# Altium schematic sheet Charging_TI_2.SchDoc(Charging_TI_2)
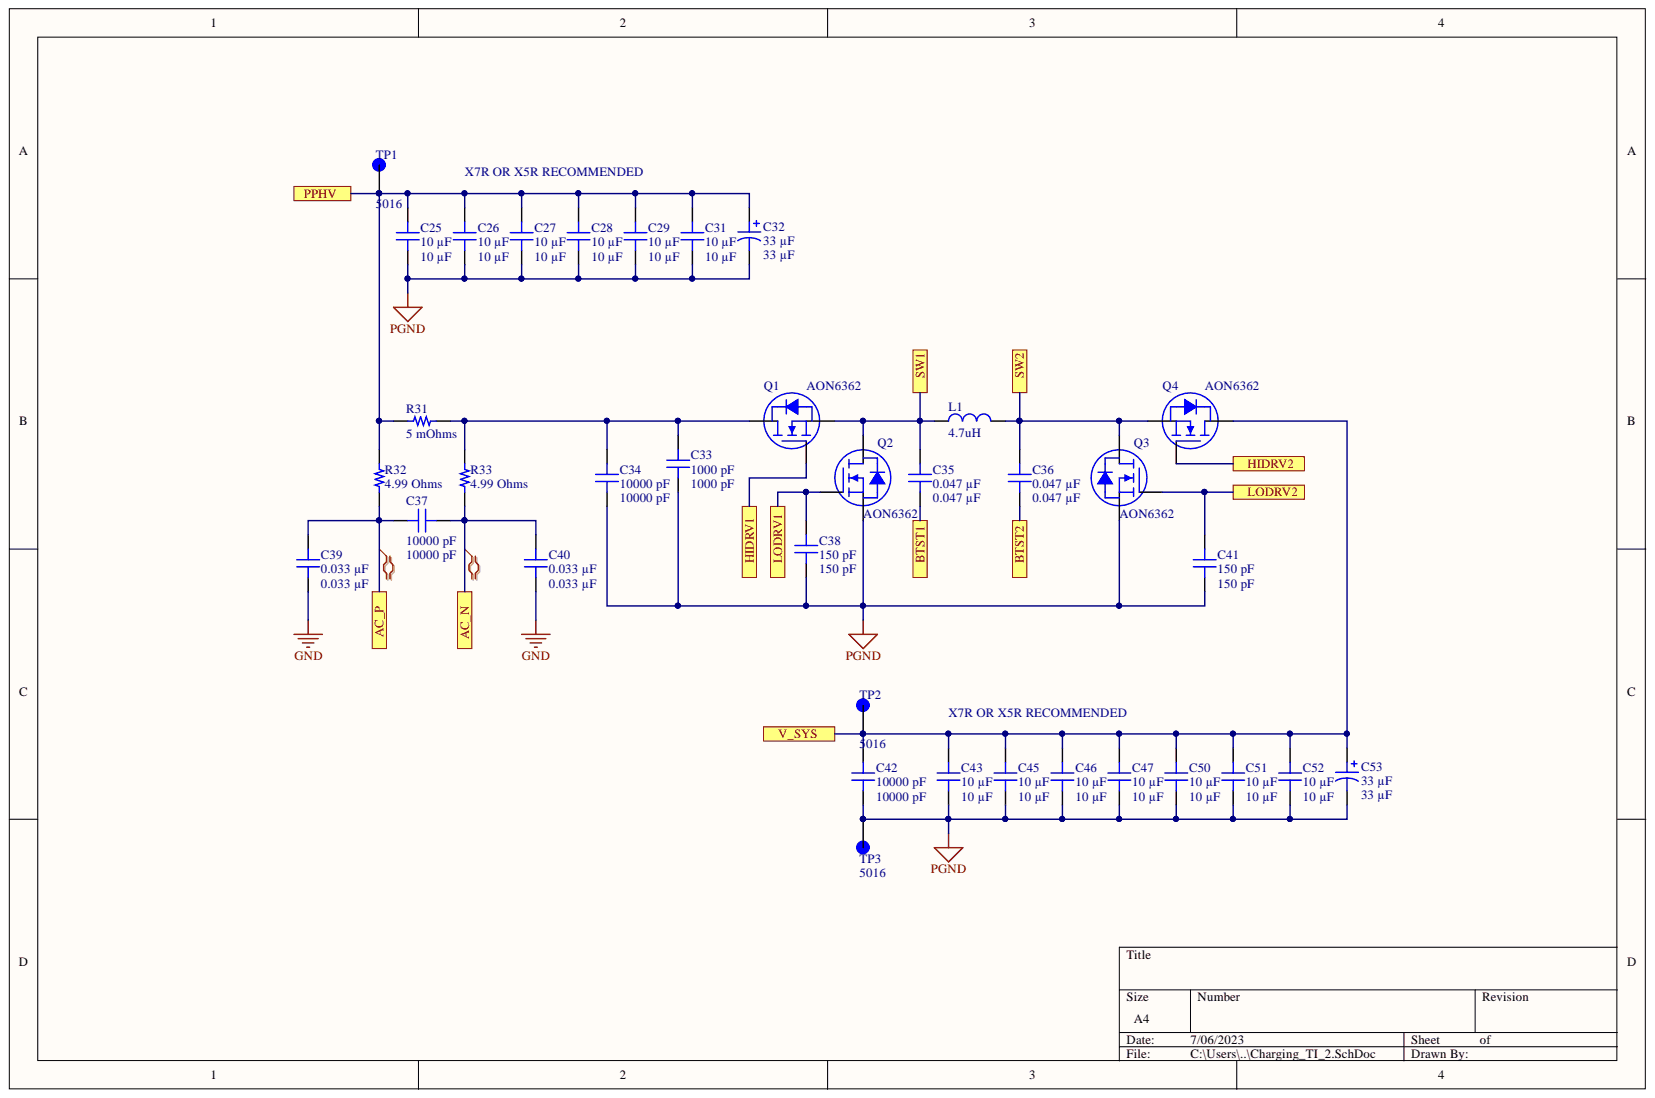
[diagram p0: Charging_TI_2.SchDoc]

## Parts
C25  10 µF  [CAP 0805_2012]
C26  10 µF  [CAP 0805_2012]
C27  10 µF  [CAP 0805_2012]
C28  10 µF  [CAP 0805_2012]
C29  10 µF  [CAP 0805_2012]
C31  10 µF  [CAP 0805_2012]
C32  33 µF  [CAP POL 2917_7343]
C33  1000 pF  [CAP 0402_1005]
C34  10000 pF  [CAP 0402_1005]
C35  0.047 µF  [CAP 0603_1608]
C36  0.047 µF  [CAP 0603_1608]
C37  10000 pF  [CAP 0603_1608]
C38  150 pF  [CAP 0603_1608]
C39  0.033 µF  [CAP 0603_1608]
C40  0.033 µF  [CAP 0603_1608]
C41  150 pF  [CAP 0603_1608]
C42  10000 pF  [CAP 0603_1608]
C43  10 µF  [CAP 0805_2012]
C45  10 µF  [CAP 0805_2012]
C46  10 µF  [CAP 0805_2012]
C47  10 µF  [CAP 0805_2012]
C50  10 µF  [CAP 0805_2012]
C51  10 µF  [CAP 0805_2012]
C52  10 µF  [CAP 0805_2012]
C53  33 µF  [CAP POL 2917_7343]
L1  4.7uH  [BMQADY1010404R7MA1]
Q1  AON6362  [DFN 5x6]
Q2  AON6362  [DFN 5x6]
Q3  AON6362  [DFN 5x6]
Q4  AON6362  [DFN 5x6]
R31  5 mOhms  [RES 1206_3216]
R32  4.99 Ohms  [RES 0603_1608]
R33  4.99 Ohms  [RES 0603_1608]
TP1  5016  [KEYSTONE_5016]
TP2  5016  [KEYSTONE_5016]
TP3  5016  [KEYSTONE_5016]

## Ports
AC_N, AC_P, BTST1, BTST2, HIDRV1, HIDRV2, LODRV1, LODRV2, PPHV, SW1, SW2, V_SYS

## Nets
GND: C39.1 C40.1
PGND: C25.1 C26.1 C27.1 C28.1 C29.1 C31.1 C32.2 C33.1 C34.1 C38.1 C41.1 C42.1 C43.1 C45.1 C46.1 C47.1 C50.1 C51.1 C52.1 C53.2 Q2.S Q3.S TP3.TP
AC_N: C37.1 C40.2 R33.1 ; port AC_N
AC_P: C37.2 C39.2 R32.1 ; port AC_P
BTST1: C35.1 ; port BTST1
BTST2: C36.1 ; port BTST2
HIDRV1: Q1.G ; port HIDRV1
HIDRV2: Q4.G ; port HIDRV2
LODRV1: C38.2 Q2.G ; port LODRV1
LODRV2: C41.2 Q3.G ; port LODRV2
PPHV: C25.2 C26.2 C27.2 C28.2 C29.2 C31.2 C32.1 R31.1 R32.2 TP1.TP ; port PPHV
SW1: C35.2 L1.1 Q1.S Q2.D ; port SW1
SW2: C36.2 L1.2 Q3.D Q4.S ; port SW2
V_SYS: C42.2 C43.2 C45.2 C46.2 C47.2 C50.2 C51.2 C52.2 C53.1 Q4.D TP2.TP ; port V_SYS
NetC33_2: C33.2 C34.2 Q1.D R31.2 R33.2

## Library data of the parts
C25-C29 C31 C43 C45-C47 C50-C52 = GRM21BR61H106KE43L: CAP CER 10UF 50V X5R 0805; manufacturer: Murata Electronics; Tolerance: ±10%; Database Table Name: Capacitors - Ceramic - 0805; Voltage - Rated: 50V; Value: 10 µF; Thickness (Max): 0.057" (1.45mm); Temperature Coefficient: X5R; Supplier Part Number 1: 490-18663-1-ND; Supplier 1: DigiKey; Size / Dimension: 0.079" L x 0.049" W (2.00mm x 1.25mm); series: GRM; price: 0.07; Part Status: Active; Part Number: GRM21BR61H106KE43L; partid: 39284; packaging: TapeAndReel; Package / Case: 0805 (2012 Metric); Operating Temperature: -55°C ~ 85°C; Mounting Type: Surface Mount, MLCC; Minimum Order: 1; Lifecycle Status: Active; Library Name: Celestial Altium Library - altium_library.DbLib; lastupdated: 14/08/2022 9:12:57 PM; Device Package: 0805 (2012 Metric); componentlink2url: https://www.digikey.com/en/products/detail/murata-electronics/GRM21BR61H106KE43L/10326316; componentlink2description: DigiKey Link; componentlink1url: https://search.murata.co.jp/Ceramy/image/img/A01X/G101/ENG/GRM21BR61H106KE43-01A.pdf; componentlink1description: Datasheet; Component Kind: Standard; Capacitance: 10 µF; Applications: General Purpose
C32 C53 = T495X336M035ATE175: CAP TANT 33UF 20% 35V 2917; Manufacturer Size Code: X; manufacturer: KEMET; Type: Molded; Lifetime @ Temp.: 2000 Hrs @ 85°C; ESR (Equivalent Series Resistance): 175mOhm; Database Table Name: Capacitors - Tantalum; Voltage - Rated: 35 V; Value: 33 µF; Tolerance: ±20%; Supplier Part Number 1: 399-5266-1-ND; Supplier 1: DigiKey; Size / Dimension: 0.287" L x 0.169" W (7.30mm x 4.30mm); series: T495; price: 1.07; Part Status: Active; Part Number: T495X336M035ATE175; partid: 698; packaging: TapeAndReel; Package / Case: 2917 (7343 Metric); Operating Temperature: -55°C ~ 125°C; Mounting Type: Surface Mount; Minimum Order: 1; Lifecycle Status: Active; Library Name: Celestial Altium Library - altium_library.DbLib; lastupdated: 14/08/2022 9:47:02 PM; Height - Seated (Max): 0.169" (4.30mm); Features: General Purpose; Device Package: 2917 (7343 Metric); componentlink2url: https://www.digikey.com/en/products/detail/kemet/T495X336M035ATE175/1833502; componentlink2description: DigiKey Link; componentlink1url: https://api.kemet.com/component-edge/download/datasheet/T495X336M035ATE175.pdf; componentlink1description: Datasheet; Component Kind: Standard; Capacitance: 33 µF
C33 = UMK105CG102JVHF: CAP CER 1000PF 50V NP0 0402; manufacturer: Taiyo Yuden; Tolerance: ±5%; Temperature Coefficient: C0G, NP0; Database Table Name: Capacitors - Ceramic - 0402; Voltage - Rated: 50V; Value: 1000 pF; Thickness (Max): 0.022" (0.55mm); Supplier Part Number 1: 587-3737-1-ND; Supplier 1: DigiKey; Size / Dimension: 0.039" L x 0.020" W (1.00mm x 0.50mm); series: M; Ratings: AEC-Q200; price: 0.01; Part Status: Active; Part Number: UMK105CG102JVHF; partid: 1779; packaging: TapeAndReel; Package / Case: 0402 (1005 Metric); Operating Temperature: -55°C ~ 125°C; Mounting Type: Surface Mount, MLCC; Minimum Order: 1; Lifecycle Status: Active; Library Name: Celestial Altium Library - altium_library.DbLib; lastupdated: 14/08/2022 9:05:57 PM; Device Package: 0402 (1005 Metric); componentlink2url: https://www.digikey.com/en/products/detail/taiyo-yuden/UMK105CG102JVHF/4811103; componentlink2description: DigiKey Link; componentlink1url: https://ds.yuden.co.jp/TYCOMPAS/ut/detail?pn=UMK105CG102JVHF &u=M; componentlink1description: Datasheet; Component Kind: Standard; Capacitance: 1000 pF; Applications: Automotive
C34 = TMK105BJ103KP-F: CAP CER 10000PF 25V X5R 0402; manufacturer: Taiyo Yuden; Tolerance: ±10%; Database Table Name: Capacitors - Ceramic - 0402; Voltage - Rated: 25V; Value: 10000 pF; Thickness (Max): 0.022" (0.55mm); Temperature Coefficient: X5R; Supplier Part Number 1: 587-5593-1-ND; Supplier 1: DigiKey; Size / Dimension: 0.039" L x 0.020" W (1.00mm x 0.50mm); series: M; price: 0.01; Part Status: Active; Part Number: TMK105BJ103KP-F; partid: 1788; packaging: TapeAndReel; Package / Case: 0402 (1005 Metric); Operating Temperature: -55°C ~ 85°C; Mounting Type: Surface Mount, MLCC; Minimum Order: 1; Lifecycle Status: Active; Library Name: Celestial Altium Library - altium_library.DbLib; lastupdated: 9/05/2023 7:07:51 PM; Device Package: 0402 (1005 Metric); componentlink2url: https://www.digikey.com/en/products/detail/taiyo-yuden/TMK105BJ103KP-F/5182051; componentlink2description: DigiKey Link; componentlink1url: https://ds.yuden.co.jp/TYCOMPAS/ut/detail?pn=TMK105BJ103KP-F  &u=M; componentlink1description: Datasheet; Component Kind: Standard; Capacitance: 10000 pF; Applications: SMPS Filtering
C35 C36 = C0603C473K3RACAUTO: CAP CER 0.047UF 25V X7R 0603; manufacturer: KEMET; Tolerance: ±10%; Database Table Name: Capacitors - Ceramic - 0603; Voltage - Rated: 25V; Value: 0.047 µF; Thickness (Max): 0.034" (0.87mm); Temperature Coefficient: X7R; Supplier Part Number 1: 399-6899-1-ND; Supplier 1: DigiKey; Size / Dimension: 0.063" L x 0.031" W (1.60mm x 0.80mm); series: SMD Auto X7R; Ratings: AEC-Q200; price: 0.02; Part Status: Active; Part Number: C0603C473K3RACAUTO; partid: 2309; packaging: TapeAndReel; Package / Case: 0603 (1608 Metric); Operating Temperature: -55°C ~ 125°C; Mounting Type: Surface Mount, MLCC; Minimum Order: 1; Lifecycle Status: Active; Library Name: Celestial Altium Library - altium_library.DbLib; lastupdated: 14/08/2022 9:09:47 PM; Device Package: 0603 (1608 Metric); componentlink2url: https://www.digikey.com/en/products/detail/kemet/C0603C473K3RACAUTO/3314894; componentlink2description: DigiKey Link; componentlink1url: https://api.kemet.com/component-edge/download/datasheet/C0603C473K3RACAUTO.pdf; componentlink1description: Datasheet; Component Kind: Standard; Capacitance: 0.047 µF; Applications: Automotive, Bypass, Decoupling
C37 C42 = CGA3E2NP01H103J080AA: CAP CER 10000PF 50V NP0 0603; manufacturer: TDK Corporation; Tolerance: ±5%; Temperature Coefficient: C0G, NP0; Database Table Name: Capacitors - Ceramic - 0603; Voltage - Rated: 50V; Value: 10000 pF; Thickness (Max): 0.035" (0.90mm); Supplier Part Number 1: 445-12383-1-ND; Supplier 1: DigiKey; Size / Dimension: 0.063" L x 0.031" W (1.60mm x 0.80mm); series: CGA; Ratings: AEC-Q200; price: 0.08; Part Status: Active; Part Number: CGA3E2NP01H103J080AA; partid: 2625; packaging: TapeAndReel; Package / Case: 0603 (1608 Metric); Operating Temperature: -55°C ~ 150°C; Mounting Type: Surface Mount, MLCC; Minimum Order: 1; Lifecycle Status: Active; Library Name: Celestial Altium Library - altium_library.DbLib; lastupdated: 14/08/2022 9:09:46 PM; Features: High Temperature; Device Package: 0603 (1608 Metric); componentlink2url: https://www.digikey.com/en/products/detail/tdk-corporation/CGA3E2NP01H103J080AA/3949619; componentlink2description: DigiKey Link; componentlink1url: https://product.tdk.com/info/en/catalog/datasheets/mlcc_automotive_hightemp_en.pdf?ref_disty=digikey; componentlink1description: Datasheet; Component Kind: Standard; Capacitance: 10000 pF; Applications: Automotive
C38 C41 = CC0603KRNPO9BN151: CAP CER 150PF 50V C0G/NPO 0603; manufacturer: Yageo; Tolerance: ±10%; Temperature Coefficient: C0G, NP0; Database Table Name: Capacitors - Ceramic - 0603; Voltage - Rated: 50V; Value: 150 pF; Thickness (Max): 0.035" (0.90mm); Supplier Part Number 1: 311-4029-1-ND; Supplier 1: DigiKey; Size / Dimension: 0.063" L x 0.031" W (1.60mm x 0.80mm); series: CC; price: 0.01; Part Status: Active; Part Number: CC0603KRNPO9BN151; partid: 3558; packaging: TapeAndReel; Package / Case: 0603 (1608 Metric); Operating Temperature: -55°C ~ 125°C; Mounting Type: Surface Mount, MLCC; Minimum Order: 1; Lifecycle Status: Active; Library Name: Celestial Altium Library - altium_library.DbLib; lastupdated: 14/08/2022 9:09:44 PM; Device Package: 0603 (1608 Metric); componentlink2url: https://www.digikey.com/en/products/detail/yageo/CC0603KRNPO9BN151/5883706; componentlink2description: DigiKey Link; componentlink1url: https://www.yageo.com/upload/media/product/productsearch/datasheet/mlcc/UPY-GP_NP0_16V-to-50V_17.pdf; componentlink1description: Datasheet; Component Kind: Standard; Capacitance: 150 pF; Applications: General Purpose
C39 C40 = C0603C333K5RACAUTO: CAP CER 0.033UF 50V X7R 0603; manufacturer: KEMET; Tolerance: ±10%; Database Table Name: Capacitors - Ceramic - 0603; Voltage - Rated: 50V; Value: 0.033 µF; Thickness (Max): 0.034" (0.87mm); Temperature Coefficient: X7R; Supplier Part Number 1: 399-6884-1-ND; Supplier 1: DigiKey; Size / Dimension: 0.063" L x 0.031" W (1.60mm x 0.80mm); series: SMD Auto X7R; Ratings: AEC-Q200; price: 0.01; Part Status: Active; Part Number: C0603C333K5RACAUTO; partid: 2224; packaging: TapeAndReel; Package / Case: 0603 (1608 Metric); Operating Temperature: -55°C ~ 125°C; Mounting Type: Surface Mount, MLCC; Minimum Order: 1; Lifecycle Status: Active; Library Name: Celestial Altium Library - altium_library.DbLib; lastupdated: 14/08/2022 9:09:47 PM; Device Package: 0603 (1608 Metric); componentlink2url: https://www.digikey.com/en/products/detail/kemet/C0603C333K5RACAUTO/3314880; componentlink2description: DigiKey Link; componentlink1url: https://api.kemet.com/component-edge/download/datasheet/C0603C333K5RACAUTO.pdf; componentlink1description: Datasheet; Component Kind: Standard; Capacitance: 0.033 µF; Applications: Automotive, Bypass, Decoupling
L1 = BMQADY1010404R7MA1: Library Name: Schlib2.SchLib; Description: BMQADY1010404R7MA1; Component Kind: Standard
Q1-Q4 = AON6362: 30V N-Channel MOSFET; Library Name: Schlib2.SchLib; Component Kind: Standard
R31 = PMR18EZPJU5L0: RES 0.005 OHM 5% 1W 1206; Manufacturer: Rohm Semiconductor; Temperature Coefficient: ±100ppm/°C; Database Table Name: Resistors - Surface Mount - 1206; Value: 5 mOhms; Tolerance: ±5%; Supplier Part Number 1: RHM.005AMCT-ND; Supplier Device Package: 1206; Supplier 1: DigiKey; Size / Dimension: 0.126" L x 0.063" W (3.20mm x 1.60mm); Series: PMR; Resistance: 5 mOhms; Ratings: AEC-Q200; Price: 0.11; Power (Watts): 1W; Part Status: Active; Part Number: PMR18EZPJU5L0; PartId: 27011; Packaging: TapeAndReel; Package / Case: 1206 (3216 Metric); Operating Temperature: -55°C ~ 155°C; Number of Terminations: 2; Minimum Order: 1; Lifecycle Status: Active; Library Name: Celestial Altium Library - altium_library.DbLib; LastUpdated: 14/08/2022 9:38:16 PM; Height - Seated (Max): 0.017" (0.43mm); Features: Automotive AEC-Q200, Current Sense; Device Package: 1206 (3216 Metric); Composition: Metal Element; ComponentLink2URL: https://www.digikey.com/en/products/detail/rohm-semiconductor/PMR18EZPJU5L0/2094212; ComponentLink2Description: DigiKey Link; ComponentLink1URL: https://www.rohm.com/datasheet/PMR18EZPFV/pmr-e; ComponentLink1Description: Datasheet; Component Kind: Standard
R32 R33 = RC0603FR-074R99L: RES 4.99 OHM 1% 1/10W 0603; Manufacturer: Yageo; Temperature Coefficient: ±200ppm/°C; Power (Watts): 0.1W, 1/10W; Database Table Name: Resistors - Surface Mount - 0603; Value: 4.99 Ohms; Tolerance: ±1%; Supplier Part Number 1: 311-4.99HRCT-ND; Supplier Device Package: 0603; Supplier 1: DigiKey; Size / Dimension: 0.063" L x 0.031" W (1.60mm x 0.80mm); Series: RC_L; Resistance: 4.99 Ohms; Price: 0.01; Part Status: Active; Part Number: RC0603FR-074R99L; PartId: 12919; Packaging: TapeAndReel; Package / Case: 0603 (1608 Metric); Operating Temperature: -55°C ~ 155°C; Number of Terminations: 2; Minimum Order: 1; Lifecycle Status: Active; Library Name: Celestial Altium Library - altium_library.DbLib; LastUpdated: 14/08/2022 9:31:08 PM; Height - Seated (Max): 0.022" (0.55mm); Features: Moisture Resistant; Device Package: 0603 (1608 Metric); Composition: Thick Film; ComponentLink2URL: https://www.digikey.com/en/products/detail/yageo/RC0603FR-074R99L/727218; ComponentLink2Description: DigiKey Link; ComponentLink1URL: https://www.yageo.com/upload/media/product/productsearch/datasheet/rchip/PYu-RC_Group_51_RoHS_L_10.pdf; ComponentLink1Description: Datasheet; Component Kind: Standard
TP1-TP3 = 5016: MF: {'manufacturer': u'Keystone Electronics'}; Price: None; Package: None; MP: 5016; Component Kind: Standard; Availability: Unavailable
